# Altium schematic sheet Regulador_Presion.SchDoc(Regulador_Presion)
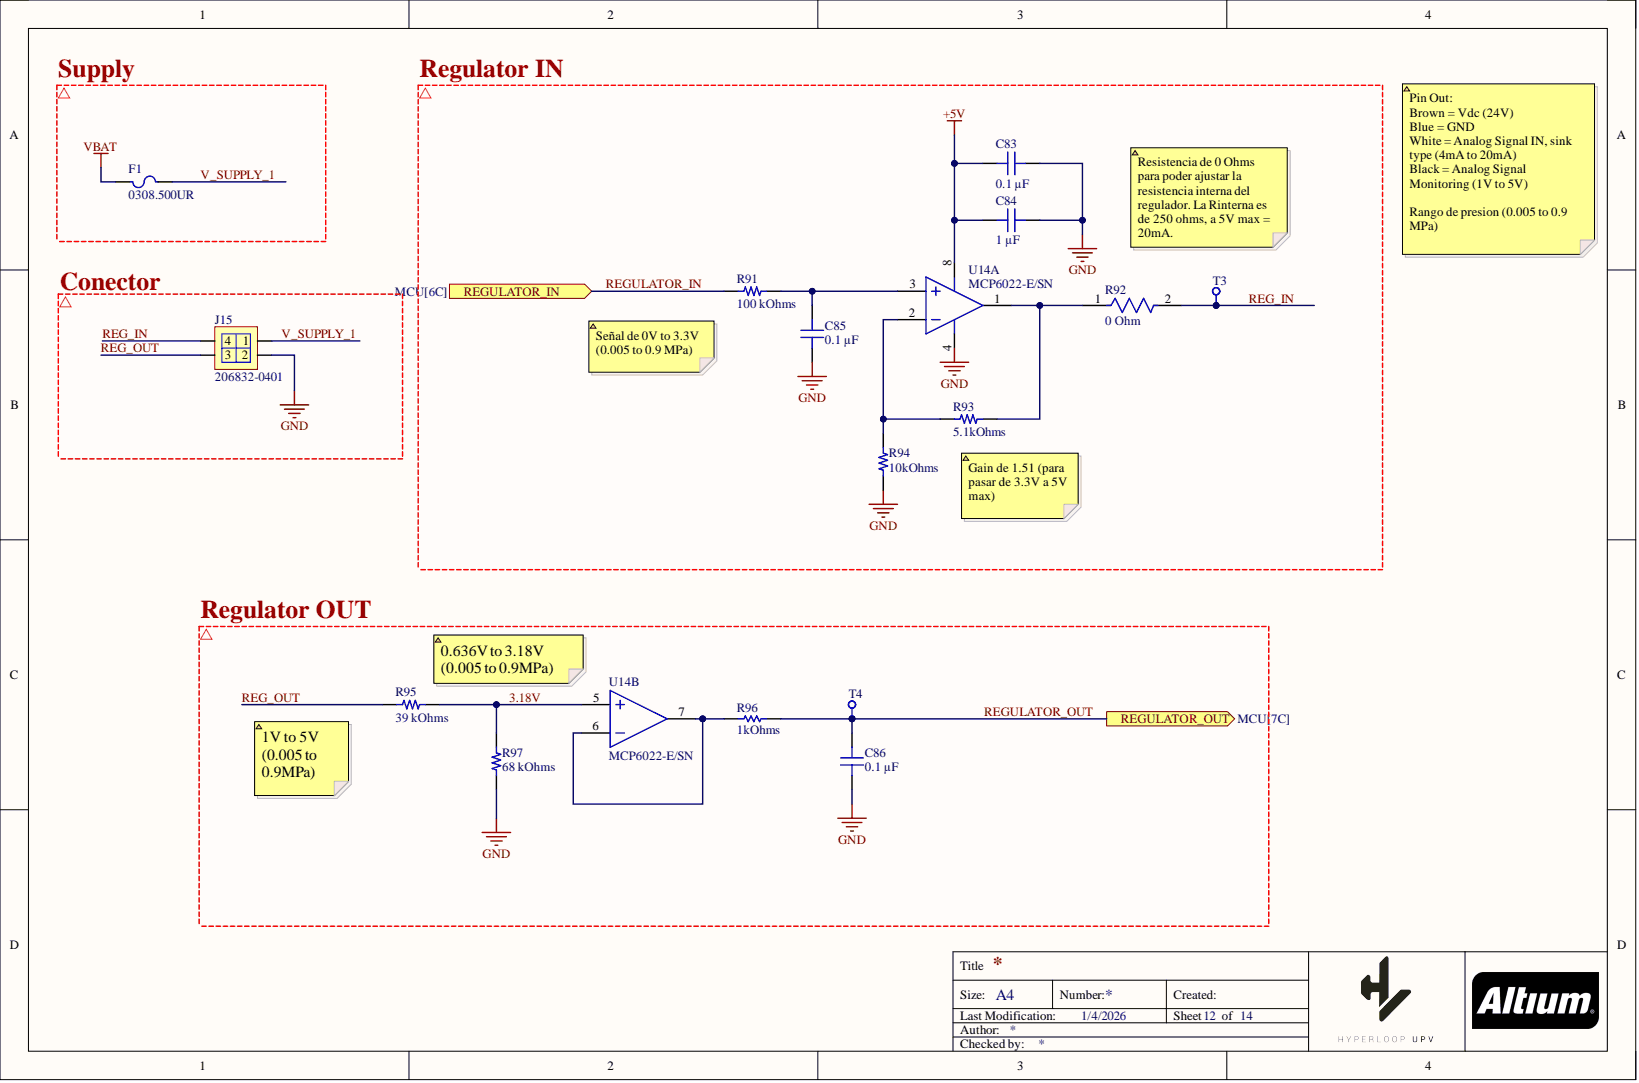
[diagram p0: Regulador_Presion.SchDoc]

## Parts
C83  0.1 µF
C84  1 µF
C85  0.1 µF
C86  0.1 µF
F1  0308.500UR
J15  206832-0401
R91  100 kOhms
R92  0 Ohm
R93  5.1kOhms
R94  10kOhms
R95  39 kOhms
R96  1kOhms
R97  68 kOhms
T3  Test point 1.2mm  (value not drawn)
T4  Test point 1.2mm  (value not drawn)
U14  MCP6022-E/SN  (parts A,B)

## Ports
REGULATOR_IN, REGULATOR_OUT

## Nets
+5V: C83.2 C84.1 U14.8
GND: C83.1 C84.2 C85.1 C86.1 J15.2 R94.1 R97.1 U14.4
VBAT: F1.1
3.18V: R95.1 R97.2 U14.5
REG_IN: J15.4 R92.2 T3.1
REG_OUT: J15.3 R95.2
REGULATOR_IN: R91.1 ; port REGULATOR_IN
REGULATOR_OUT: C86.2 R96.2 T4.1 ; port REGULATOR_OUT
V_SUPPLY_1: F1.2 J15.1
NetC85_2: C85.2 R91.2 U14.3
NetR92_1: R92.1 R93.1 U14.1
NetR93_2: R93.2 R94.2 U14.2
NetR96_1: R96.1 U14.6 U14.7

## Library data of the parts
C83 = C0603X104K050T: CAP CER 0.1UF 50V X7R 0603; manufacturer: Holy Stone Enterprise Co., Ltd.; Database Table Name: Capacitors - Ceramic - 0603; Tolerance: ±10%; Applications: SMPS Filtering; Capacitance: 0.1 µF; Component Kind: Standard; componentlink1description: Datasheet; componentlink1url: https://www.holystonecaps.com/PDF/201612051329340.2017%20NCC%20Series.pdf; componentlink2description: DigiKey Link; componentlink2url: https://www.digikey.com/en/products/detail/holy-stone-enterprise-co-ltd/C0603X104K050T/16894915; Device Package: 0603 (1608 Metric); lastupdated: 2/9/2024 8:09:56 PM; Library Name: Celestial Altium Library - altium_library.DbLib; Lifecycle Status: Active; Minimum Order: 1; Mounting Type: Surface Mount, MLCC; Operating Temperature: -55°C ~ 125°C; Package / Case: 0603 (1608 Metric); packaging: TapeAndReel; Part Number: C0603X104K050T; Part Status: Active; partid: 35023; price: 0.01; series: MVC; Size / Dimension: 0.063" L x 0.031" W (1.60mm x 0.80mm); Supplier 1: DigiKey; Supplier Part Number 1: 4587-C0603X104K050TCT-ND; Temperature Coefficient: X7R; Thickness (Max): 0.039" (1.00mm); Value: 0.1 µF; Voltage - Rated: 50V
C84 = C1206C105K5RACAUTO: CAP CER 1UF 50V X7R 1206; manufacturer: KEMET; Database Table Name: Capacitors - Ceramic - 1206; Tolerance: ±10%; Applications: Automotive, Bypass, Decoupling; Capacitance: 1 µF; Component Kind: Standard; componentlink1description: Datasheet; componentlink1url: https://api.kemet.com/component-edge/download/datasheet/C1206C105K5RACAUTO.pdf; componentlink2description: DigiKey Link; componentlink2url: https://www.digikey.com/en/products/detail/kemet/C1206C105K5RACAUTO/3315003; Device Package: 1206 (3216 Metric); lastupdated: 6/4/2024 7:10:32 PM; Library Name: Celestial Altium Library - altium_library.DbLib; Lifecycle Status: Active; Minimum Order: 1; Mounting Type: Surface Mount, MLCC; Operating Temperature: -55°C ~ 125°C; Package / Case: 1206 (3216 Metric); packaging: TapeAndReel; Part Number: C1206C105K5RACAUTO; Part Status: Active; partid: 19104; price: 0.05; Ratings: AEC-Q200; series: SMD Auto X7R; Size / Dimension: 0.126" L x 0.063" W (3.20mm x 1.60mm); Supplier 1: DigiKey; Supplier Part Number 1: 399-7011-1-ND; Temperature Coefficient: X7R; Thickness (Max): 0.043" (1.10mm); Value: 1 µF; Voltage - Rated: 50V
C85 C86 = CGA3E2X7R1H104K080AE: CAP CER 0.1UF 50V X7R 0603; manufacturer: TDK Corporation; Database Table Name: Capacitors - Ceramic - 0603; Tolerance: ±10%; Applications: Automotive, Boardflex Sensitive; Capacitance: 0.1 µF; Component Kind: Standard; componentlink1description: Datasheet; componentlink1url: https://product.tdk.com/info/en/catalog/datasheets/mlcc_automotive_soft_en.pdf?ref_disty=digikey; componentlink2description: DigiKey Link; componentlink2url: https://www.digikey.com/en/products/detail/tdk-corporation/CGA3E2X7R1H104K080AE/4490594; Device Package: 0603 (1608 Metric); Features: Soft Termination; lastupdated: 2/9/2024 8:10:29 PM; Library Name: Celestial Altium Library - altium_library.DbLib; Lifecycle Status: Active; Minimum Order: 1; Mounting Type: Surface Mount, MLCC; Operating Temperature: -55°C ~ 125°C; Package / Case: 0603 (1608 Metric); packaging: TapeAndReel; Part Number: CGA3E2X7R1H104K080AE; Part Status: Active; partid: 2715; price: 0.01; Ratings: AEC-Q200; series: CGA; Size / Dimension: 0.063" L x 0.031" W (1.60mm x 0.80mm); Supplier 1: DigiKey; Supplier Part Number 1: 445-15957-1-ND; Temperature Coefficient: X7R; Thickness (Max): 0.037" (0.95mm); Value: 0.1 µF; Voltage - Rated: 50V
F1 = CMP-006-00255-1: Fuse 0.5A SMD 30V; Current Rating: 500mA; Component Kind: Standard; Max Operating Temperature: -40°C; Min Operating Temperature: 70°C; Mounting Technology: SMD; Pins: 2; RoHS Compliant: YES; Library Name: Hyperloop UPV
J15 = CMP-006-00301-4: MICRO-FIT Plus Header Assembly Dual Row 4 Position Straight Tin; Connector Type: Micro-Fit+; Gender: Male; Max Current: 12.5 A; Max Voltage: 600V AC (RMS)/DC; Component Kind: Standard; Max Operating Temperature: 105°C; Min Operating Temperature: -40°C; Mounting Technology: THT; Pins: 4; RoHS Compliant: Yes; Library Name: Hyperloop UPV
R91 = CRCW0603100KFKEAC: RES 100K OHM 1% 1/10W 0603; Manufacturer: Vishay Dale; Database Table Name: Resistors - Surface Mount - 0603; Power (Watts): 0.1W, 1/10W; Temperature Coefficient: ±100ppm/°C; Component Kind: Standard; ComponentLink1Description: Datasheet; ComponentLink1URL: http://www.vishay.com/docs/28773/crcwce3.pdf; ComponentLink2Description: DigiKey Link; ComponentLink2URL: https://www.digikey.com/en/products/detail/vishay-dale/CRCW0603100KFKEAC/7928372; Composition: Thick Film; Device Package: 0603 (1608 Metric); Height - Seated (Max): 0.022" (0.55mm); LastUpdated: 2/9/2024 8:43:06 PM; Library Name: Celestial Altium Library - altium_library.DbLib; Lifecycle Status: Active; Minimum Order: 1; Number of Terminations: 2; Operating Temperature: -55°C ~ 155°C; Package / Case: 0603 (1608 Metric); Packaging: CutTape; Part Number: CRCW0603100KFKEAC; Part Status: Active; PartId: 12549; Price: 0.01; Resistance: 100 kOhms; Series: CRCW-C; Supplier 1: DigiKey; Supplier Device Package: 0603; Supplier Part Number 1: 541-3950-1-ND; Tolerance: ±1%; Value: 100 kOhms
R92 = CMP-03211-011415-1: RES SMD 0 OHM JUMPER 1/10W 0603; Max Power Dissipation: 100mW; Number of Resistors: 1; Resistance: 0mR; Temperature Coefficient: 100ppm/°C; Tolerance: 0%; Voltage Rating: 50V; Case Code (Imperial): 0603; Case Code (Metric): 1608; Case/Package: 0603; Component Kind: Standard; Depth: 0.8mm; ELV: Compliant; Height: 0.45mm; Length: 1.6mm; Library Name: Altium Content Vault; Max Operating Temperature: 125°C; Min Operating Temperature: -55°C; Mount: Surface Mount; Number of Terminations: 2; Package Quantity: 5000; Packaging: Tape and Reel; Power Rating: 100mW; Radiation Hardening: No; Resistor Type: Thick Film; RoHS Compliant: Yes; Series: CRG, Neohm; Technology: THICK FILM; Termination: Solder; Weight: 7.1E-05oz; Width: 0.8mm
R93 R95 = RT0603FRE0710KL: RES SMD 10K OHM 1% 1/10W 0603; Manufacturer: Yageo; Database Table Name: Resistors - Surface Mount - 0603; Power (Watts): 0.1W, 1/10W; Resistance: 10 kOhms; Temperature Coefficient: ±50ppm/°C; Component Kind: Standard; ComponentLink1Description: Datasheet; ComponentLink1URL: https://www.yageo.com/upload/media/product/productsearch/datasheet/rchip/PYu-RT_1-to-0.01_RoHS_L_11.pdf; ComponentLink2Description: DigiKey Link; ComponentLink2URL: https://www.digikey.com/en/products/detail/yageo/RT0603FRE0710KL/1075040; Composition: Thin Film; Device Package: 0603 (1608 Metric); Height - Seated (Max): 0.022" (0.55mm); LastUpdated: 7/25/2024 9:19:32 AM; Library Name: Celestial Altium Library - altium_library.DbLib; Lifecycle Status: Active; Minimum Order: 1; Number of Terminations: 2; Operating Temperature: -55°C ~ 155°C; Package / Case: 0603 (1608 Metric); Packaging: TapeAndReel; Part Number: RT0603FRE0710KL; Part Status: Active; PartId: 5671; Price: 0.01; Series: RT; Size / Dimension: 0.063" L x 0.031" W (1.60mm x 0.80mm); Supplier 1: DigiKey; Supplier Device Package: 0603; Supplier Part Number 1: YAG2321CT-ND; Tolerance: ±1%
  R93: Value: 5.1kOhms
  R95: Value: 39 kOhms
R94 = RT0603BRD074K7L: RES SMD 4.7K OHM 0.1% 1/10W 0603; Manufacturer: Yageo; Database Table Name: Resistors - Surface Mount - 0603; Power (Watts): 0.1W, 1/10W; Resistance: 4.7 kOhms; Temperature Coefficient: ±25ppm/°C; Component Kind: Standard; ComponentLink1Description: Datasheet; ComponentLink1URL: https://www.yageo.com/upload/media/product/productsearch/datasheet/rchip/PYu-RT_1-to-0.01_RoHS_L_11.pdf; ComponentLink2Description: DigiKey Link; ComponentLink2URL: https://www.digikey.com/en/products/detail/yageo/RT0603BRD074K7L/1072561; Composition: Thin Film; Device Package: 0603 (1608 Metric); Height - Seated (Max): 0.022" (0.55mm); LastUpdated: 7/25/2024 9:19:29 AM; Library Name: Celestial Altium Library - altium_library.DbLib; Lifecycle Status: Active; Minimum Order: 1; Number of Terminations: 2; Operating Temperature: -55°C ~ 155°C; Package / Case: 0603 (1608 Metric); Packaging: TapeAndReel; Part Number: RT0603BRD074K7L; Part Status: Active; PartId: 10325; Price: 0.03; Series: RT; Size / Dimension: 0.063" L x 0.031" W (1.60mm x 0.80mm); Supplier 1: DigiKey; Supplier Device Package: 0603; Supplier Part Number 1: YAG1676CT-ND; Tolerance: ±0.1%; Value: 10kOhms
R96 = CMP-2000-04305-1: Manufacturer: Yageo; Part Number: RC0603JR-101KL; Component Kind: Standard; Library Name: Altium Content Vault
R97 = RMCF0603FT6K80: RES 6.8K OHM 1% 1/10W 0603; Manufacturer: Stackpole Electronics Inc; Database Table Name: Resistors - Surface Mount - 0603; Power (Watts): 0.1W, 1/10W; Resistance: 6.8 kOhms; Temperature Coefficient: ±100ppm/°C; Component Kind: Standard; ComponentLink1Description: Datasheet; ComponentLink1URL: https://www.seielect.com/catalog/sei-rmcf_rmcp.pdf; ComponentLink2Description: DigiKey Link; ComponentLink2URL: https://www.digikey.com/en/products/detail/stackpole-electronics-inc/RMCF0603FT6K80/1760790; Composition: Thick Film; Device Package: 0603 (1608 Metric); Features: Automotive AEC-Q200; Height - Seated (Max): 0.022" (0.55mm); LastUpdated: 2/9/2024 8:43:14 PM; Library Name: Celestial Altium Library - altium_library.DbLib; Lifecycle Status: Active; Minimum Order: 1; Number of Terminations: 2; Operating Temperature: -55°C ~ 155°C; Package / Case: 0603 (1608 Metric); Packaging: CutTape; Part Number: RMCF0603FT6K80; Part Status: Active; PartId: 10603; Price: 0.01; Ratings: AEC-Q200; Series: RMCF; Size / Dimension: 0.061" L x 0.031" W (1.55mm x 0.80mm); Supplier 1: DigiKey; Supplier Device Package: 0603; Supplier Part Number 1: RMCF0603FT6K80CT-ND; Tolerance: ±1%; Value: 68 kOhms
T3 T4 = CMP-006-00250-2: 1.2mm Test point; Component Kind: Standard; Library Name: Hyperloop UPV
U14 = CMP-00005-4: Operational Amplifier, 2 Func, 2500uV Offset-Max, CMOS, PDSO8; Architecture: VOLTAGE-FEEDBACK; Average Bias Current-Max (IIB): 5nA; Common-mode Reject Ratio-Nom: 90dB; Frequency Compensation: YES; Input Offset Voltage-Max: 250uV; Low-Offset: No; Max Supply Current: 2.7mA; Number of Functions: 2; Package Body Material: Plastic; Package Equivalence Code: SOP8,.25; Power Supplies: 3/5V; Qualification Status: Not Qualified; Slew Rate: 7V/µs; Supply Voltage Limit-Max: 7V; Supply Voltage-Nom (Vsup): 5V; Time @ Peak Reflow Temperature-Max (s): 40s; Unity Gain BW-Nom: 10MHz; Voltage Gain-Min: 31620; Amplifier Type: OPERATIONAL AMPLIFIER; Component Kind: Standard; Height - Seated (Max): 1.75mm; JESD-30 Code: R-PDSO-G8; JESD-609 Code: e3; Length: 4.9mm; Low-Bias: Yes; Max Operating Temperature: 125°C; Micropower: No; Min Operating Temperature: -40°C; Moisture Sensitivity Level: 3; Number of Pins: 8; Package Code: SOP; Package Shape: Rectangular; Package Style: SMALL OUTLINEMeter; Packaging: Tape and Reel; Peak Reflow Temperature (Cel): 260°C; Power: No; Programmable Power: No; Reach SVHC Compliant: Yes; RoHS Compliant: Yes; Surface Mount: Yes; Technology: CMOS; Temperature Grade: AUTOMOTIVE; Terminal Finish: Tin; Terminal Form: Gull Wing; Terminal Pitch: 1.27mm; Terminal Position: DUAL; Wideband: No; Width: 3.91mm; Library Name: Hyperloop UPV
